# Altium schematic sheet Sheet1.SchDoc(Sheet1)
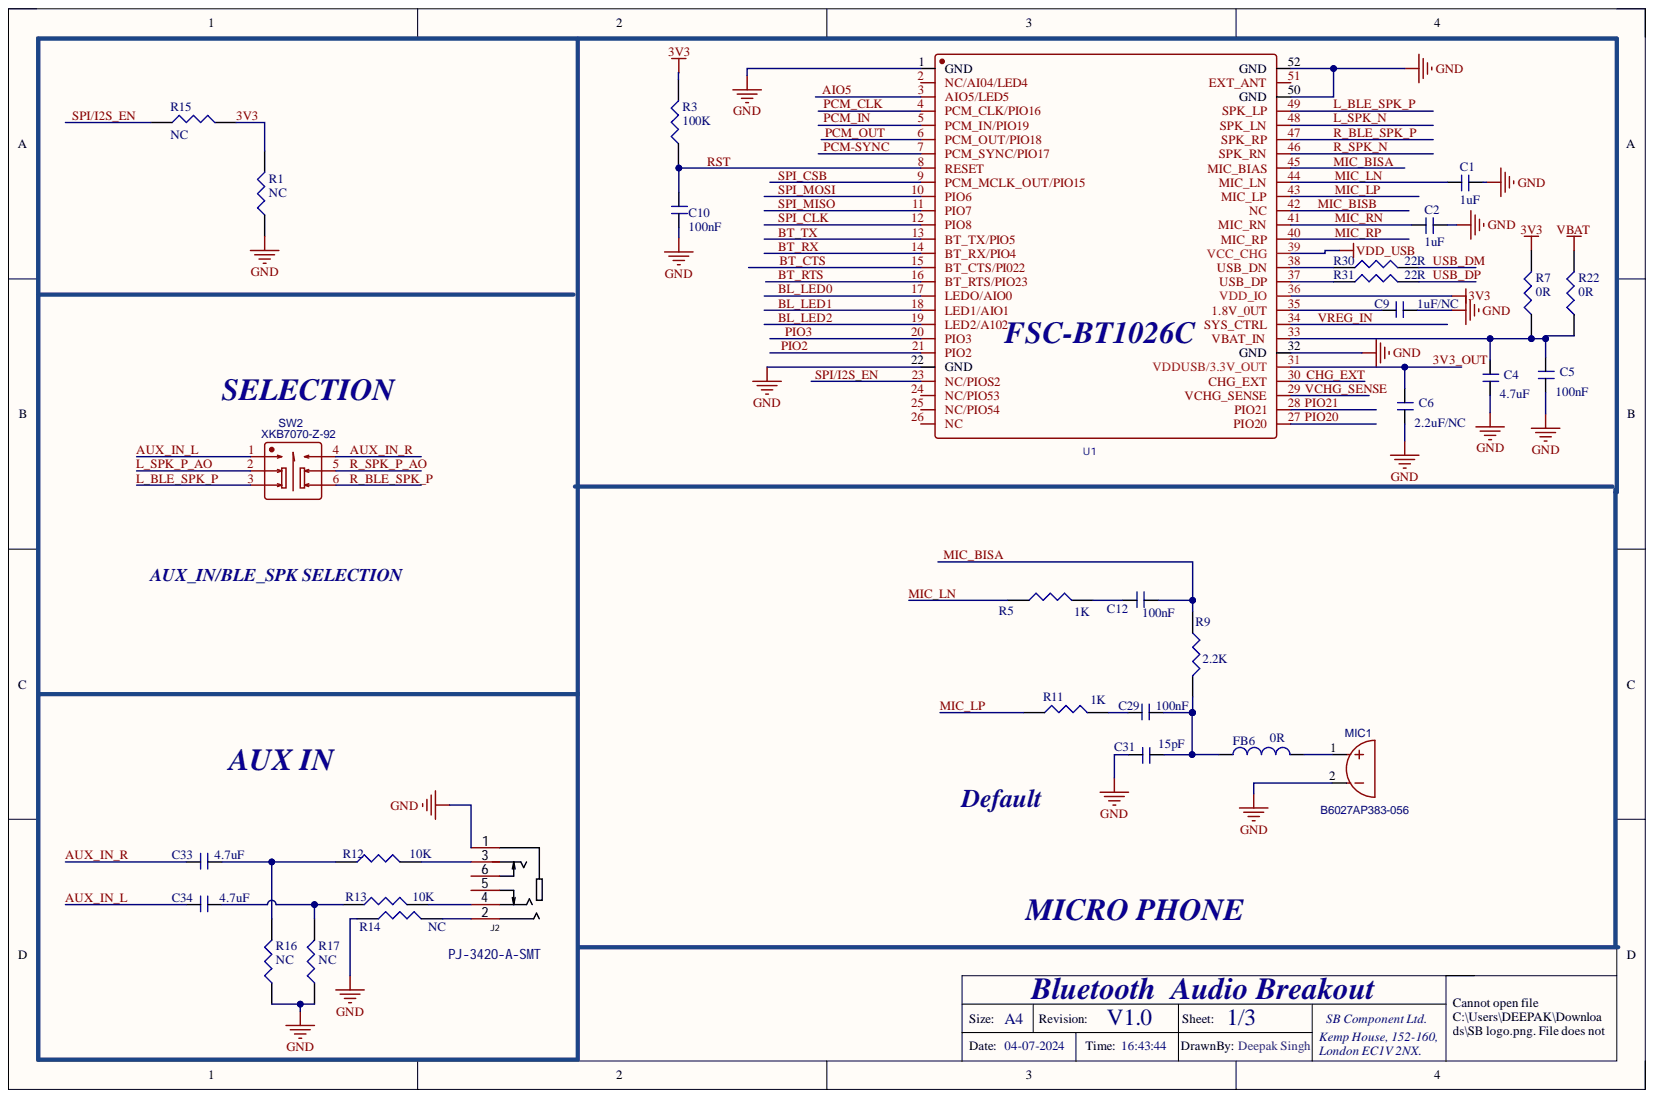
[diagram p0: Sheet1.SchDoc]

## Parts
C1  1uF  [C_0603]
C2  1uF  [C_0603]
C4  4.7uF  [C_0603]
C5  100nF  [C_0603]
C6  2.2uF/NC  [C_0603]
C9  1uF/NC  [C_0603]
C10  100nF  [C_0603]
C12  100nF  [C_0603]
C29  100nF  [C_0603]
C31  15pF  [C_0603]
C33  4.7uF  [C_0603]
C34  4.7uF  [C_0603]
FB6  FB  value 0R  [R_0603]
J2  PJ-3420-A-SMT  [3.5mm_PJ-3420]
MIC1  B6027AP383-056  [Mic D=6mm]
R1  NC  [R_0603]
R3  100K  [R_0603]
R5  1K  [R_0603]
R7  0R  [R_0603]
R9  2.2K  [R_0603]
R11  1K  [R_0603]
R12  10K  [R_0603]
R13  10K  [R_0603]
R14  NC  [R_0603]
R15  NC  [R_0603]
R16  NC  [R_0603]
R17  NC  [R_0603]
R22  0R  [R_0603]
R30  22R  [R_0603]
R31  22R  [R_0603]
SW2  XKB7070-Z-92  [PUSH SWITCH_6P]
U1  (no value)  [FSC-BT1026]

## Nets
GND: C1.1 C2.1 C4.2 C5.2 C6.2 C9.2 C10.2 C31.2 J2.1 MIC1.2 R1.1 R14.2 R16.2 R17.2 U1.1(GND) U1.22(GND) U1.32(GND) U1.50(GND) U1.52(GND)
VBAT: R22.2
VDD_USB: U1.39(VCC_CHG)
3V3: R1.2 R3.2 R7.2 R15.2 U1.36(VDD_IO)
3V3_OUT: C6.1 U1.31(VDDUSB/3.3V_OUT)
AIO5: U1.3(AIO5/LED5)
AUX_IN_L: C34.1 SW2.1
AUX_IN_R: C33.1 SW2.4
BL_LED0: U1.17(LEDO/AIO0)
BL_LED1: U1.18(LED1/AIO1)
BL_LED2: U1.19(LED2/A102)
BT_CTS: U1.15(BT_CTS/PI022)
BT_RTS: U1.16(BT_RTS/PIO23)
BT_RX: U1.14(BT_RX/PIO4)
BT_TX: U1.13(BT_TX/PIO5)
CHG_EXT: U1.30(CHG_EXT)
L_BLE_SPK_P: SW2.3 U1.49(SPK_LP)
L_SPK_N: U1.48(SPK_LN)
L_SPK_P_AO: SW2.2
MIC_BISA: C12.2 R9.1 U1.45(MIC_BIAS)
MIC_BISB: U1.42(NC)
MIC_LN: C1.2 R5.1 U1.44(MIC_LN)
MIC_LP: R11.1 U1.43(MIC_LP)
MIC_RN: C2.2 U1.41(MIC_RN)
MIC_RP: U1.40(MIC_RP)
PCM-SYNC: U1.7(PCM_SYNC/PIO17)
PCM_CLK: U1.4(PCM_CLK/PIO16)
PCM_IN: U1.5(PCM_IN/PIO19)
PCM_OUT: U1.6(PCM_OUT/PIO18)
PIO2: U1.21(PIO2)
PIO3: U1.20(PIO3)
PIO20: U1.27(PIO20)
PIO21: U1.28(PIO21)
R_BLE_SPK_P: SW2.6 U1.47(SPK_RP)
R_SPK_N: U1.46(SPK_RN)
R_SPK_P_AO: SW2.5
RST: C10.1 R3.1 U1.8(RESET)
SPI/I2S_EN: R15.1 U1.23(NC/PIOS2)
SPI_CLK: U1.12(PIO8)
SPI_CSB: U1.9(PCM_MCLK_OUT/PIO15)
SPI_MISO: U1.11(PIO7)
SPI_MOSI: U1.10(PIO6)
USB_DM: R30.2
USB_DP: R31.2
VCHG_SENSE: U1.29(VCHG_SENSE)
VREG_IN: U1.34(SYS_CTRL)
NetC4_1: C4.1 C5.1 R7.1 R22.1 U1.33(VBAT_IN)
NetC9_1: C9.1 U1.35(1.8V_0UT)
NetC12_1: C12.1 R5.2
NetC29_1: C29.1 R11.2
NetC29_2: C29.2 C31.1 FB6.1 R9.2
NetC33_2: C33.2 R12.2 R16.1
NetC34_2: C34.2 R13.2 R17.1
NetFB6_2: FB6.2 MIC1.1
NetJ2_2: J2.2 R14.1
NetJ2_3: J2.3 R12.1
NetJ2_4: J2.4 R13.1
NetR30_1: R30.1 U1.38(USB_DN)
NetR31_1: R31.1 U1.37(USB_DP)

Not connected: J2 5 6; U1 2(NC/AI04/LED4) 24(NC/PIO53) 25(NC/PIO54) 26(NC) 51(EXT_ANT)

## Library data of the parts
C1 C2 C4-C6 C9 C10 C12 C29 C31 C33 C34 = CAP_NONPOLAR: Component Kind: Standard; Library Name: dxp_pasl_lib.IntLib
FB6 = Inductor: Code_IEC: 1005; Code_JEITA: 0402; Component Kind: Standard; Description: Inductor; LatestRevisionDate: 29-May-2009; LatestRevisionNote: IPC-7351 Footprint Added.; Library Name: Miscellaneous Devices.IntLib; PackageDescription: Chip Inductor; PackageReference: 0402-A; Published: 8-Jun-2000; Signal Integrity: Inductor; Simulation: INDUCTOR; Publisher: Altium Limited
J2 = PJ-3420-A-SMT: Manufacturer: XKB Connectivity(中国星坤); Add into BOM: yes; Connector Type: 3.5mm耳机座; Current Rating (Max): 500mA; Soldering Temperature(Max): 235℃; Symbol: PJ-3537-X; Voltage Rating (Max): 30V; Component Kind: Standard; Convert to PCB: yes; Datasheet: https://atta.szlcsc.com/upload/public/pdf/source/20211015/FA11597DF53DC331FB6A72D64BA50234.pdf; Device: PJ-3420-A-SMT; JLCPCB Part Class: Extended Part; LCSC Part Name: 3.5mm耳机座; Manufacturer Part: PJ-3420-A-SMT; Operating Temperature Range: -20℃~+70℃; Supplier: LCSC; Supplier Footprint: SMD; Supplier Part: C319102
MIC1 = B6027AP383-056: BOM_Manufacturer: Goertek(歌尔); Contributor: lcsc; BOM_Manufacturer Part: B6027AP383-056; BOM_Supplier: LCSC; BOM_Supplier Part: C5160215; Component Kind: Standard; link: https://atta.szlcsc.com/upload/public/pdf/source/20220914/97BA7D4D29A741F4A78B16123EEEBF89.pdf; spicePre: M; spiceSymbolName: B6027AP383-056
R1 R3 R5 R7 R9 R11-R17 R22 R30 R31 = RES: Component Kind: Standard; Library Name: dxp_pasl_lib.IntLib
SW2 = XKB7070-Z-92: Manufacturer: XKB Connectivity(中国星坤); Contributor: LCSC; Component Kind: Standard; JLCPCB Part Class: Extended Part; Manufacturer Part: XKB7070-Z-92; spicePre: S; spiceSymbolName: XKB7070-Z-92; Supplier: LCSC; Supplier Part: C318862
U1 = FSC-BT1026C(蓝牙模块): Component Kind: Standard
